# Altium schematic sheet MAIN.SchDoc(MAIN)
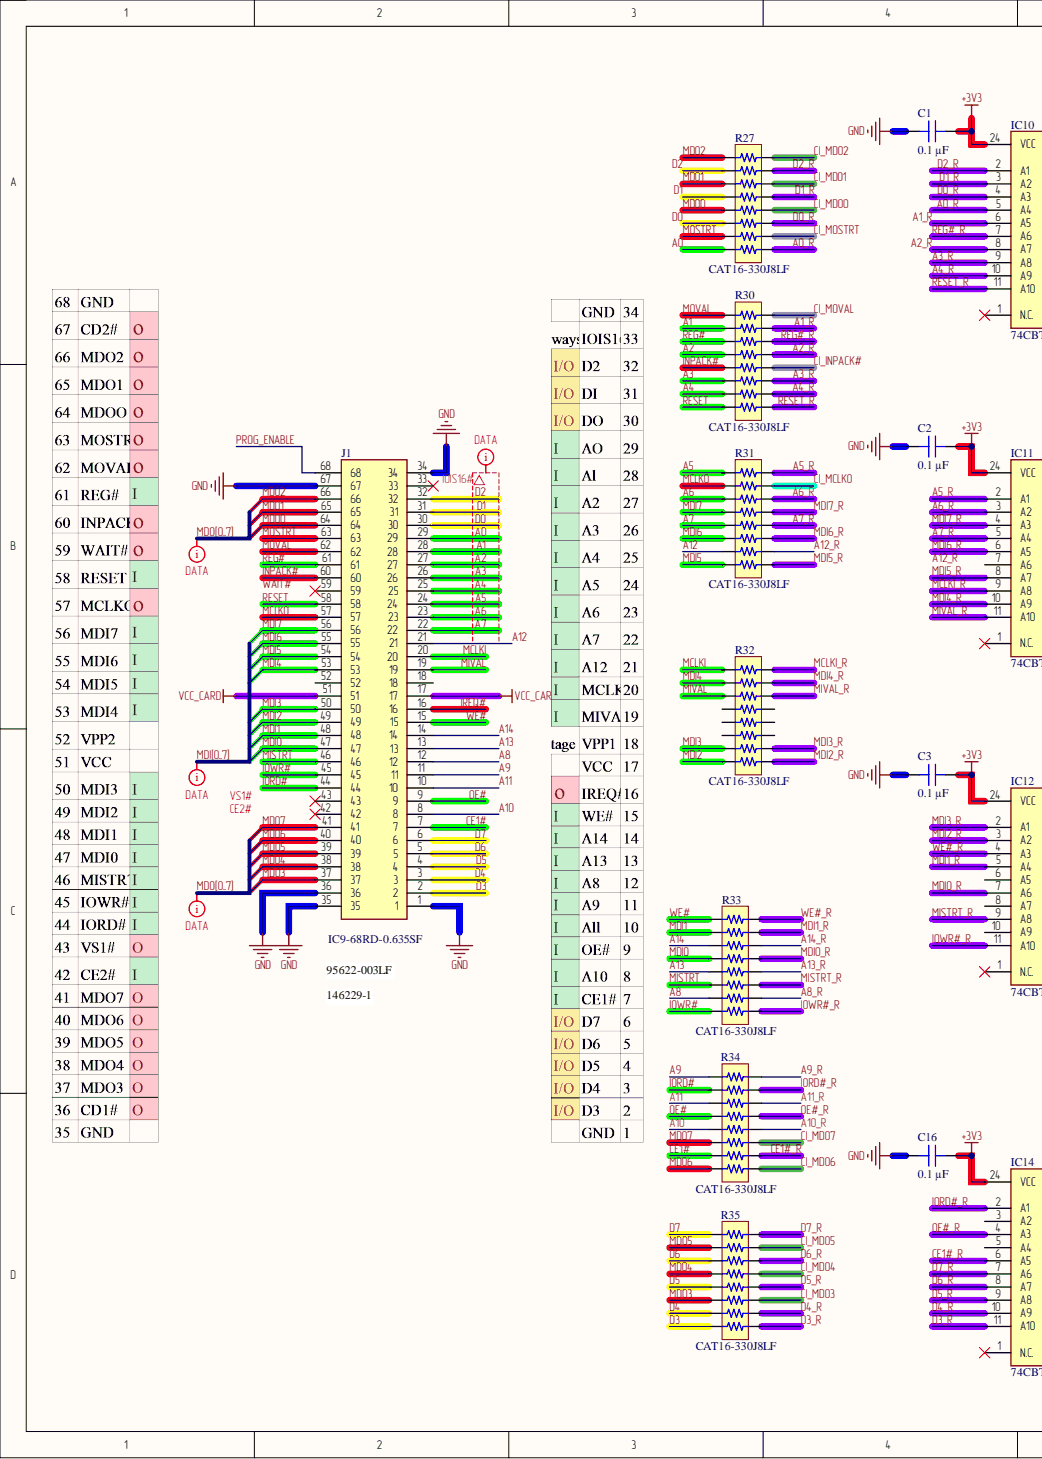
[diagram p0: MAIN.SchDoc, left]
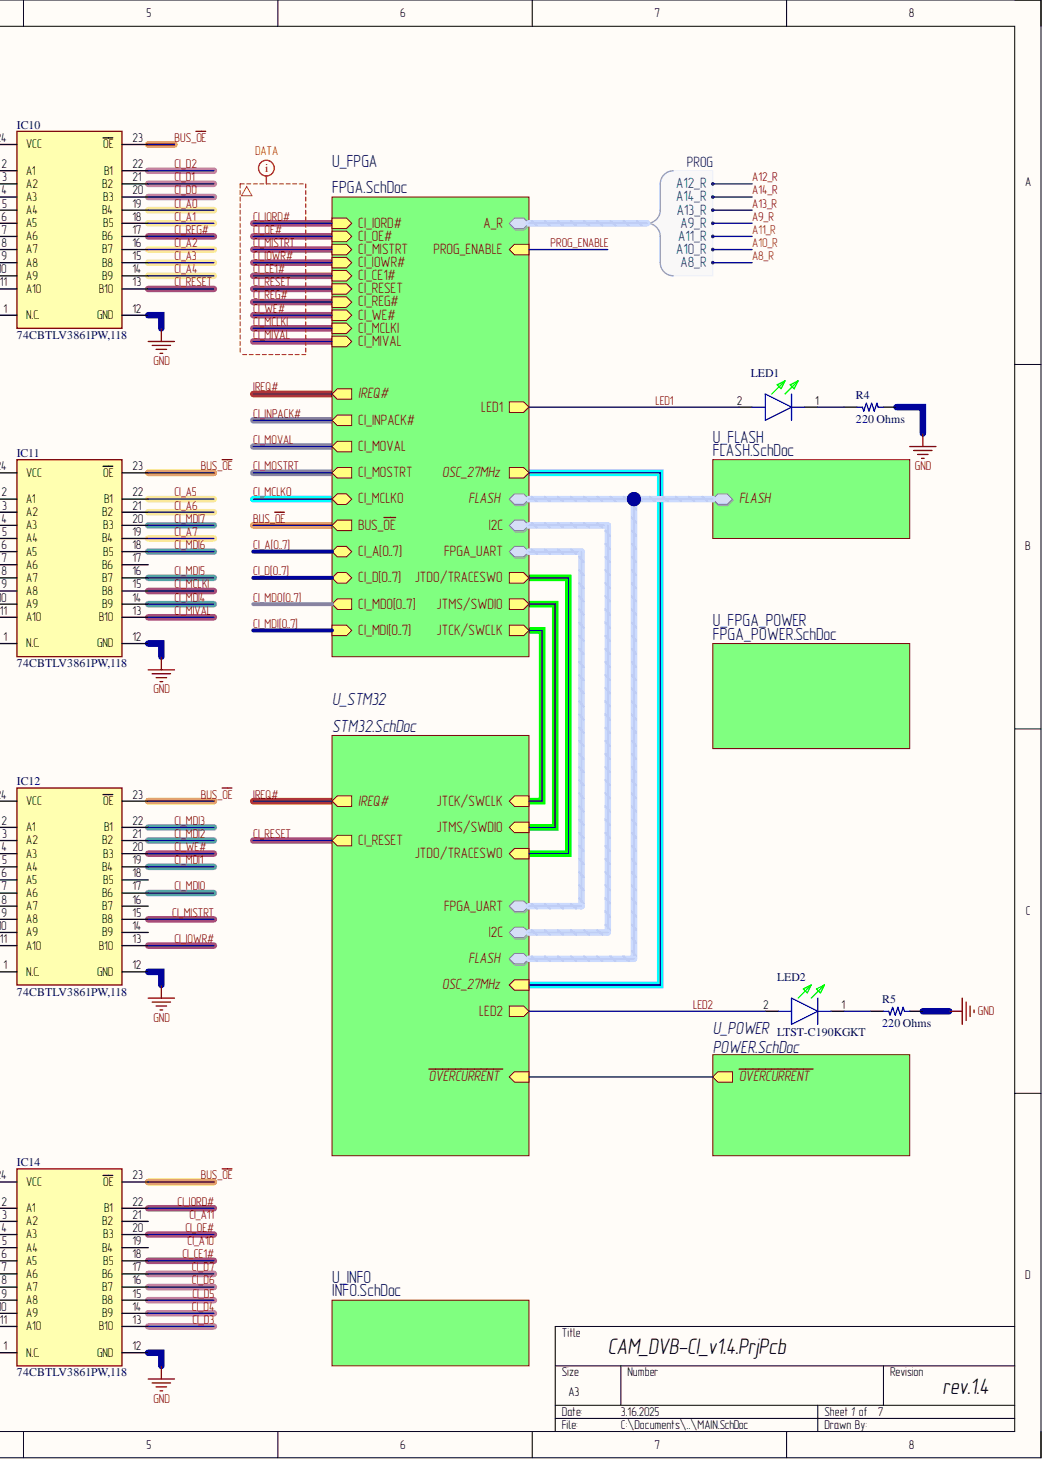
[diagram p1: MAIN.SchDoc, right]

## Parts
C1  0.1 µF  [CAP 0402_1005]
C2  0.1 µF  [CAP 0402_1005]
C3  0.1 µF  [CAP 0402_1005]
C16  0.1 µF  [CAP 0402_1005]
IC10  74CBTLV3861PW,118  [SOP65P640X110-24N]
IC11  74CBTLV3861PW,118  [SOP65P640X110-24N]
IC12  74CBTLV3861PW,118  [SOP65P640X110-24N]
IC14  74CBTLV3861PW,118  [SOP65P640X110-24N]
J1  IC9-68RD-0.635SF  [IC9-68RD-0.635SF]
LED1  (no value)  [LEDC1608X80N]
LED2  LTST-C190KGKT  [LEDC1608X80N]
R4  220 Ohms  [RES 0603_1608]
R5  220 Ohms  [RES 0603_1608]
R27  CAT16-330J8LF  [RESNET 2506-16 CONCAVE]
R30  CAT16-330J8LF  [RESNET 2506-16 CONCAVE]
R31  CAT16-330J8LF  [RESNET 2506-16 CONCAVE]
R32  CAT16-330J8LF  [RESNET 2506-16 CONCAVE]
R33  CAT16-330J8LF  [RESNET 2506-16 CONCAVE]
R34  CAT16-330J8LF  [RESNET 2506-16 CONCAVE]
R35  CAT16-330J8LF  [RESNET 2506-16 CONCAVE]

## Nets
+3V3: C1.2 C2.2 C3.2 C16.2 IC10.24(VCC) IC11.24(VCC) IC12.24(VCC) IC14.24(VCC)
GND: C1.1 C2.1 C3.1 C16.1 IC10.12(GND) IC11.12(GND) IC12.12(GND) IC14.12(GND) J1.1 J1.34 J1.35 J1.36 J1.67 R4.2 R5.2
VCC_CARD: J1.17 J1.51
A0: J1.29 R27.8
A0_R: IC10.5(A4) R27.9
A1: J1.28 R30.2
A1_R: IC10.6(A5) R30.15
A2: J1.27 R30.4
A2_R: IC10.8(A7) R30.13
A3: J1.26 R30.6
A3_R: IC10.9(A8) R30.11
A4: J1.25 R30.7
A4_R: IC10.10(A9) R30.10
A5: J1.24 R31.1
A5_R: IC11.2(A1) R31.16
A6: J1.23 R31.3
A6_R: IC11.3(A2) R31.14
A7: J1.22 R31.5
A7_R: IC11.5(A4) R31.12
A8: J1.12 R33.7
A8_R: R33.10
A9: J1.11 R34.1
A9_R: R34.16
A10: J1.8 R34.5
A10_R: R34.12
A11: J1.10 R34.3
A11_R: R34.14
A12: J1.21 R31.7
A12_R: R31.10
A13: J1.13 R33.5
A13_R: R33.12
A14: J1.14 R33.3
A14_R: R33.14
BUS_~{OE}: IC10.23(OE) IC11.23(OE) IC12.23(OE) IC14.23(OE)
CE1#: J1.7 R34.7
CE1#_R: IC14.6(A5) R34.10
CI_A0: IC10.19(B4)  [also labelled CI_A]
CI_A1: IC10.18(B5)  [also labelled CI_A]
CI_A2: IC10.16(B7)  [also labelled CI_A]
CI_A3: IC10.15(B8)  [also labelled CI_A]
CI_A4: IC10.14(B9)  [also labelled CI_A]
CI_A5: IC11.22(B1)  [also labelled CI_A]
CI_A6: IC11.21(B2)  [also labelled CI_A]
CI_A7: IC11.19(B4)  [also labelled CI_A]
CI_CE1#: IC14.18(B5)
CI_D0: IC10.20(B3)  [also labelled CI_D]
CI_D1: IC10.21(B2)  [also labelled CI_D]
CI_D2: IC10.22(B1)  [also labelled CI_D]
CI_D3: IC14.13(B10)  [also labelled CI_D]
CI_D4: IC14.14(B9)  [also labelled CI_D]
CI_D5: IC14.15(B8)  [also labelled CI_D]
CI_D6: IC14.16(B7)  [also labelled CI_D]
CI_D7: IC14.17(B6)  [also labelled CI_D]
CI_INPACK#: R30.12
CI_IORD#: IC14.22(B1)
CI_IOWR#: IC12.13(B10)
CI_MCLKI: IC11.15(B8)
CI_MCLKO: R31.15
CI_MDI0: IC12.17(B6)  [also labelled CI_MDI]
CI_MDI1: IC12.19(B4)  [also labelled CI_MDI]
CI_MDI2: IC12.21(B2)  [also labelled CI_MDI]
CI_MDI3: IC12.22(B1)  [also labelled CI_MDI]
CI_MDI4: IC11.14(B9)  [also labelled CI_MDI]
CI_MDI5: IC11.16(B7)  [also labelled CI_MDI]
CI_MDI6: IC11.18(B5)  [also labelled CI_MDI]
CI_MDI7: IC11.20(B3)  [also labelled CI_MDI]
CI_MDO0: R27.12  [also labelled CI_MDO]
CI_MDO1: R27.14  [also labelled CI_MDO]
CI_MDO2: R27.16  [also labelled CI_MDO]
CI_MDO3: R35.11  [also labelled CI_MDO]
CI_MDO4: R35.13  [also labelled CI_MDO]
CI_MDO5: R35.15  [also labelled CI_MDO]
CI_MDO6: R34.9  [also labelled CI_MDO]
CI_MDO7: R34.11  [also labelled CI_MDO]
CI_MISTRT: IC12.15(B8)
CI_MIVAL: IC11.13(B10)
CI_MOSTRT: R27.10
CI_MOVAL: R30.16
CI_OE#: IC14.20(B3)
CI_REG#: IC10.17(B6)
CI_RESET: IC10.13(B10)
CI_WE#: IC12.20(B3)
D0: J1.30 R27.6
D0_R: IC10.4(A3) R27.11
D1: J1.31 R27.4
D1_R: IC10.3(A2) R27.13
D2: J1.32 R27.2
D2_R: IC10.2(A1) R27.15
D3: J1.2 R35.8
D3_R: IC14.11(A10) R35.9
D4: J1.3 R35.7
D4_R: IC14.10(A9) R35.10
D5: J1.4 R35.5
D5_R: IC14.9(A8) R35.12
D6: J1.5 R35.3
D6_R: IC14.8(A7) R35.14
D7: J1.6 R35.1
D7_R: IC14.7(A6) R35.16
INPACK#: J1.60 R30.5
IORD#: J1.44 R34.2
IORD#_R: IC14.2(A1) R34.15
IOWR#: J1.45 R33.8
IOWR#_R: IC12.11(A10) R33.9
IREQ#: J1.16
LED1: LED1.2
LED2: LED2.2
MCLKI: J1.20 R32.1
MCLKI_R: IC11.9(A8) R32.16
MCLKO: J1.57 R31.2
MDI0: J1.47 R33.4  [also labelled MDI]
MDI0_R: IC12.7(A6) R33.13
MDI1: J1.48 R33.2  [also labelled MDI]
MDI1_R: IC12.5(A4) R33.15
MDI2: J1.49 R32.8  [also labelled MDI]
MDI2_R: IC12.3(A2) R32.9
MDI3: J1.50 R32.7  [also labelled MDI]
MDI3_R: IC12.2(A1) R32.10
MDI4: J1.53 R32.2  [also labelled MDI]
MDI4_R: IC11.10(A9) R32.15
MDI5: J1.54 R31.8  [also labelled MDI]
MDI5_R: IC11.8(A7) R31.9
MDI6: J1.55 R31.6  [also labelled MDI]
MDI6_R: IC11.6(A5) R31.11
MDI7: J1.56 R31.4  [also labelled MDI]
MDI7_R: IC11.4(A3) R31.13
MDO0: J1.64 R27.5  [also labelled MDO]
MDO1: J1.65 R27.3  [also labelled MDO]
MDO2: J1.66 R27.1  [also labelled MDO]
MDO3: J1.37 R35.6  [also labelled MDO]
MDO4: J1.38 R35.4  [also labelled MDO]
MDO5: J1.39 R35.2  [also labelled MDO]
MDO6: J1.40 R34.8  [also labelled MDO]
MDO7: J1.41 R34.6  [also labelled MDO]
MISTRT: J1.46 R33.6
MISTRT_R: IC12.9(A8) R33.11
MIVAL: J1.19 R32.3
MIVAL_R: IC11.11(A10) R32.14
MOSTRT: J1.63 R27.7
MOVAL: J1.62 R30.1
OE#: J1.9 R34.4
OE#_R: IC14.4(A3) R34.13
PROG_ENABLE: J1.68
REG#: J1.61 R30.3
REG#_R: IC10.7(A6) R30.14
RESET: J1.58 R30.8
RESET_R: IC10.11(A10) R30.9
WE#: J1.15 R33.1
WE#_R: IC12.4(A3) R33.16
NetIC10_1: IC10.1(N.C.)
NetIC11_1: IC11.1(N.C.)
NetIC11_7: IC11.7(A6)
NetIC11_17: IC11.17(B6)
NetIC12_1: IC12.1(N.C.)
NetIC12_6: IC12.6(A5)
NetIC12_8: IC12.8(A7)
NetIC12_10: IC12.10(A9)
NetIC12_14: IC12.14(B9)
NetIC12_16: IC12.16(B7)
NetIC12_18: IC12.18(B5)
NetIC14_1: IC14.1(N.C.)
NetIC14_3: IC14.3(A2)
NetIC14_5: IC14.5(A4)
NetIC14_19: IC14.19(B4)
NetIC14_21: IC14.21(B2)
NetJ1_18: J1.18
NetJ1_33: J1.33
NetJ1_42: J1.42
NetJ1_43: J1.43
NetJ1_52: J1.52
NetJ1_59: J1.59
NetLED1_1: LED1.1 R4.1
NetLED2_1: LED2.1 R5.1
NetR32_4: R32.4
NetR32_5: R32.5
NetR32_6: R32.6
NetR32_11: R32.11
NetR32_12: R32.12
NetR32_13: R32.13
~{OVERCURRENT}:
CI_A10:
CI_A11:
FLASH.CS:
FLASH.MISO:
FLASH.MOSI:
FLASH.SCK:
FPGA_UART.CTS:
FPGA_UART.RTS:
FPGA_UART.RX:
FPGA_UART.TX:
I2C.1WIRE:
I2C.SCL:
I2C.SDA:
JTCK/SWCLK:
JTDO/TRACESWO:
JTMS/SWDIO:
OSC_27MHz:
WAIT#:

## Library data of the parts
C1-C3 C16 = CC0402KRX7R7BB104: CAP CER 0.1UF 16V X7R 0402; manufacturer: Yageo; Database Table Name: Capacitors - Ceramic - 0402; Tolerance: ±10%; Applications: General Purpose; Capacitance: 0.1 µF; Component Kind: Standard; componentlink1description: Datasheet; componentlink1url: https://www.yageo.com/upload/media/product/productsearch/datasheet/mlcc/UPY-GPHC_X7R_6.3V-to-50V_18.pdf; componentlink2description: DigiKey Link; componentlink2url: https://www.digikey.com/en/products/detail/yageo/CC0402KRX7R7BB104/2103076; Device Package: 0402 (1005 Metric); lastupdated: 09.02.2024 20:04:34; Library Name: Celestial Altium Library - altium_library.DbLib; Lifecycle Status: Active; Minimum Order: 10; Mounting Type: Surface Mount, MLCC; Operating Temperature: -55°C ~ 125°C; Package / Case: 0402 (1005 Metric); packaging: TapeAndReel; Part Number: CC0402KRX7R7BB104; Part Status: Active; partid: 4014; price: 0.01; series: CC; Size / Dimension: 0.039" L x 0.020" W (1.00mm x 0.50mm); Supplier 1: DigiKey; Supplier Part Number 1: 311-1338-1-ND; Temperature Coefficient: X7R; Thickness (Max): 0.022" (0.55mm); Value: 0.1 µF; Voltage - Rated: 16V
IC10-IC12 IC14 = 74CBTLV3861PW,118: Integrated Circuit; Manufacturer_Name: Nexperia; Component Kind: Standard; Datasheet Link: https://assets.nexperia.com/documents/data-sheet/74CBTLV3861.pdf; Height: 1.1mm; Library Name: SamacSys.SchLib; Manufacturer_Part_Number: 74CBTLV3861PW,118
J1 = IC9-68RD-0.635SF: Connector Card Bus Socket; Manufacturer_Name: Hirose; Component Kind: Standard; Height: 2.83mm; Library Name: SamacSys.SchLib; Manufacturer_Part_Number: IC9-68RD-0.635SF
LED1 LED2 = LTST-C190KGKT: LED, 0603, GREEN; Manufacturer_Name: Lite-On; Arrow Part Number: LTST-C190KGKT; Arrow Price/Stock: https://www.arrow.com/en/products/ltst-c190kgkt/lite-on-technology?region=europe; Component Kind: Standard; Datasheet Link: http://uk.rs-online.com/web/p/visible-leds/6920988/; Height: 0.8mm; Library Name: SamacSys.SchLib; Manufacturer_Part_Number: LTST-C190KGKT; Mouser Part Number: 859-LTST-C190KGKT; Mouser Price/Stock: https://www.mouser.co.uk/ProductDetail/Lite-On/LTST-C190KGKT?qs=205qCy6M2Q%252BAdcgwUZktqw%3D%3D
R4 R5 = RC0603FR-07220RL: RES 220 OHM 1% 1/10W 0603; Manufacturer: Yageo; Database Table Name: Resistors - Surface Mount - 0603; Power (Watts): 0.1W, 1/10W; Temperature Coefficient: ±100ppm/°C; Component Kind: Standard; ComponentLink1Description: Datasheet; ComponentLink1URL: https://www.yageo.com/upload/media/product/productsearch/datasheet/rchip/PYu-RC_Group_51_RoHS_L_10.pdf; ComponentLink2Description: DigiKey Link; ComponentLink2URL: https://www.digikey.com/en/products/detail/yageo/RC0603FR-07220RL/727061; Composition: Thick Film; Device Package: 0603 (1608 Metric); Features: Moisture Resistant; Height - Seated (Max): 0.022" (0.55mm); LastUpdated: 09.02.2024 20:42:42; Library Name: Celestial Altium Library - altium_library.DbLib; Lifecycle Status: Active; Minimum Order: 1; Number of Terminations: 2; Operating Temperature: -55°C ~ 155°C; Package / Case: 0603 (1608 Metric); Packaging: CutTape; Part Number: RC0603FR-07220RL; Part Status: Active; PartId: 18935; Price: 0.01; Resistance: 220 Ohms; Series: RC_L; Size / Dimension: 0.063" L x 0.031" W (1.60mm x 0.80mm); Supplier 1: DigiKey; Supplier Device Package: 0603; Supplier Part Number 1: 311-220HRCT-ND; Tolerance: ±1%; Value: 220 Ohms
R27 R30-R35 = CAT16-330J8LF: RES ARRAY 33 OHM 8 RES 2506; Manufacturer: Bourns Inc.; Circuit Type: Isolated; Database Table Name: Resistors -  Arrays and Networks; Number of Resistors: 8; Power Per Element: 62.5mW; Resistance (Ohms): 33; Temperature Coefficient: ±200ppm/°C; Tolerance: ±5%; Component Kind: Standard; ComponentLink1Description: Datasheet; ComponentLink1URL: https://www.bourns.com/docs/Product-Datasheets/CATCAY.pdf; ComponentLink2Description: DigiKey Link; ComponentLink2URL: http://digikey.com/product-detail/en/bourns-inc/CAT16-330J8LF/CAT16-330J8LFCT-ND/5355703; Height - Seated (Max): 0.030" (0.75mm); LastUpdated: 03.06.2024 20:04:15; Library Name: Celestial Altium Library - altium_library.DbLib; Lifecycle Status: Active; Minimum Order: 1; Mounting Type: Surface Mount; Number of Pins: 16; Operating Temperature: -55°C ~ 125°C; Package / Case: 2506, Concave, Long Side Terminals; Packaging: TapeAndReel; Part Number: CAT16-330J8LF; Part Status: Active; PartId: 29; Price: 0.06; Series: CAT16; Size / Dimension: 0.252" L x 0.063" W (6.40mm x 1.60mm); Supplier 1: DigiKey; Supplier Device Package: 2406; Supplier Part Number 1: CAT16-330J8LFCT-ND
